# Altium schematic sheet ESP32.SchDoc(ESP32)
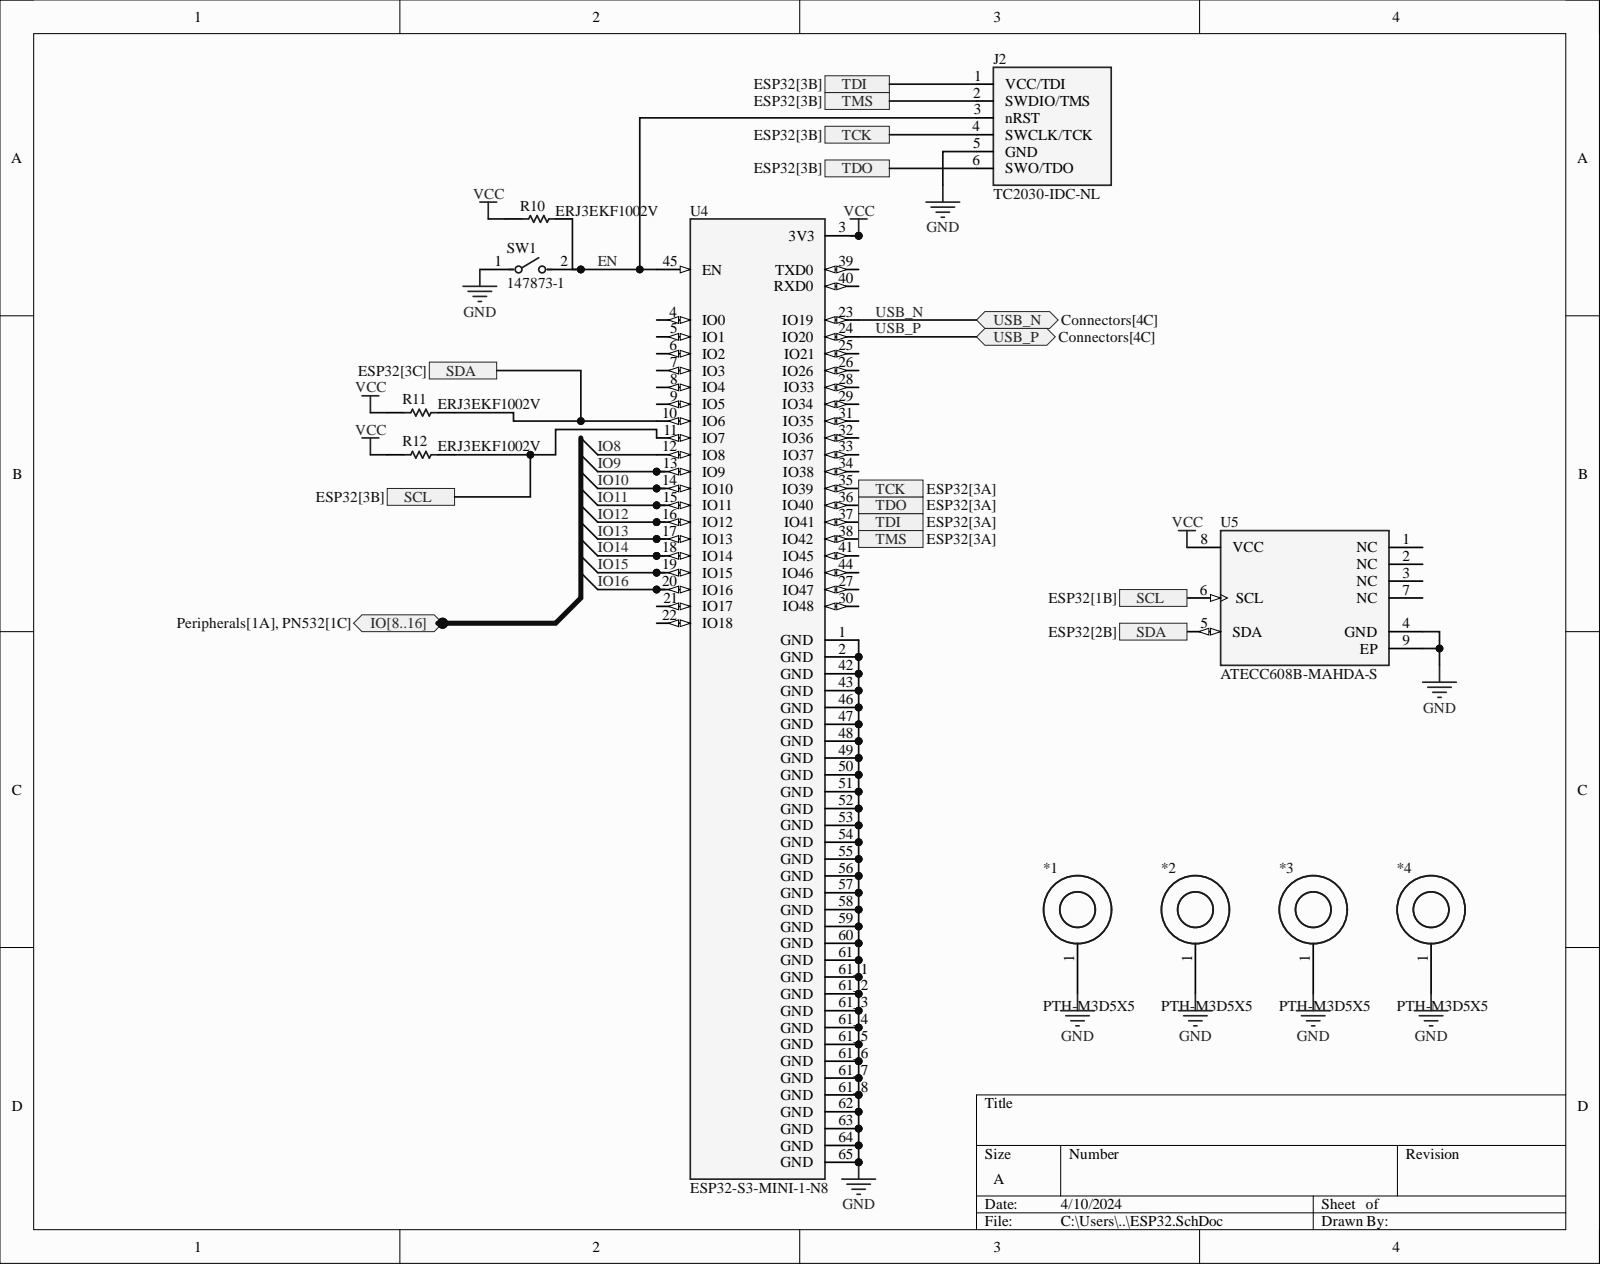
[diagram p0: ESP32.SchDoc]

## Parts
*1  PTH-M3D5X5  [PTH-M3D5X5-Footprint-1]
*2  PTH-M3D5X5  [PTH-M3D5X5-Footprint-1]
*3  PTH-M3D5X5  [PTH-M3D5X5-Footprint-1]
*4  PTH-M3D5X5  [PTH-M3D5X5-Footprint-1]
J2  TC2030-IDC-NL  [TAG_TC2030-IDC-NL]
R10  ERJ3EKF1002V  [RESC1609X55X30LL15T15]
R11  ERJ3EKF1002V  [RESC1609X55X30LL15T15]
R12  ERJ3EKF1002V  [RESC1609X55X30LL15T15]
SW1  147873-1  [FP-147873-1-MFG]
U4  ESP32-S3-MINI-1-N8  [XCVR_ESP32-S3-MINI-1-N8]
U5  ATECC608B-MAHDA-S  [FP-C04-21355-Q4B-IPC_B]

## Ports
IO8, IO9, IO10, IO11, IO12, IO13, IO14, IO15, IO16, IO[8..16], SCL, SDA, TCK, TDI, TDO, TMS, USB_N, USB_P

## Nets
GND: *1.1 *2.1 *3.1 *4.1 J2.5(GND) SW1.1 U4.1(GND) U4.2(GND) U4.42(GND) U4.43(GND) U4.46(GND) U4.47(GND) U4.48(GND) U4.49(GND) U4.50(GND) U4.51(GND) U4.52(GND) U4.53(GND) U4.54(GND) U4.55(GND) U4.56(GND) U4.57(GND) U4.58(GND) U4.59(GND) U4.60(GND) U4.61(GND) U4.61_1(GND) U4.61_2(GND) U4.61_3(GND) U4.61_4(GND) U4.61_5(GND) U4.61_6(GND) U4.61_7(GND) U4.61_8(GND) U4.62(GND) U4.63(GND) U4.64(GND) U4.65(GND) U5.4(GND) U5.9(EP)
VCC: R10.2 R11.2 R12.2 U4.3(3V3) U5.8(VCC)
EN: J2.3(nRST) R10.1 SW1.2 U4.45(EN)
IO8: U4.12(IO8) ; port IO[8..16]  [also labelled ]
IO9: U4.13(IO9) ; port IO[8..16]  [also labelled ]
IO10: U4.14(IO10) ; port IO[8..16]  [also labelled ]
IO11: U4.15(IO11) ; port IO[8..16]  [also labelled ]
IO12: U4.16(IO12) ; port IO[8..16]  [also labelled ]
IO13: U4.17(IO13) ; port IO[8..16]  [also labelled ]
IO14: U4.18(IO14) ; port IO[8..16]  [also labelled ]
IO15: U4.19(IO15) ; port IO[8..16]  [also labelled ]
IO16: U4.20(IO16) ; port IO[8..16]  [also labelled ]
SCL: R12.1 U4.11(IO7) U5.6(SCL) ; port SCL
SDA: R11.1 U4.10(IO6) U5.5(SDA) ; port SDA
TCK: J2.4(SWCLK/TCK) U4.35(IO39) ; port TCK
TDI: J2.1(VCC/TDI) U4.37(IO41) ; port TDI
TDO: J2.6(SWO/TDO) U4.36(IO40) ; port TDO
TMS: J2.2(SWDIO/TMS) U4.38(IO42) ; port TMS
USB_N: U4.23(IO19) ; port USB_N
USB_P: U4.24(IO20) ; port USB_P

Not connected: U4 4(IO0) 5(IO1) 6(IO2) 7(IO3) 8(IO4) 9(IO5) 21(IO17) 22(IO18) 25(IO21) 26(IO26) 27(IO47) 28(IO33) 29(IO34) 30(IO48) 31(IO35) 32(IO36) 33(IO37) 34(IO38) 39(TXD0) 40(RXD0) 41(IO45) 44(IO46); U5 1(NC) 2(NC) 3(NC) 7(NC)

## Library data of the parts
*1-*4 = CMP-010-00024-1: Plated Thru-Hole M3 D5X5; Component Kind: Standard; Mounting Technology: TH; Pins: 1; Library Name: Purdue University
J2 = CMP-070-000001-2: CABLE ADAPTER 6 POS; Availability: Unavailable; Component Kind: Standard; Component Type: Standard; Design Item ID: TC2030-IDC-NL; MP: TC2030-IDC-NL; Package: None; Pin Count: 6; Price: None; Source: TC2030-IDC-NL.SchLib; Library Name: Purdue University
R10-R12 = CMP-1012-00623-3: Chip Resistor, 10 KOhm, +/- 1%, 0.1 W, -55 to 155 degC, 0603 (1608 Metric), RoHS, Tape and Reel; Manufacturer: Panasonic; Case\/Package: 0603; Max Power Dissipation: 100mW; Resistance: 10KOhm; Temperature Coefficient: 100ppm\/degreesC; Voltage Rating: 75V; Case Code (Imperial): 0603; Case Code (Metric): 1608; Component Kind: Standard; Composition: ThickFilm; Contact Plating: Tin; Datasheet URL: https://industrial.panasonic.com/cdbs/www-data/pdf/RDA0000/AOA0000C304.pdf; Datasheet Version: 02/2017; Depth: 0.8mm; Height: 0.45mm; Lead Free: LeadFree; Length: 1.6mm; Library Name: Altium Content Vault; Manufacturer URL: http://www.panasonic.com/; Max Operating Temperature: 155degC; Military Standard: Not; Min Operating Temperature: -55degC; Mount: SurfaceMount; Mounting Technology: SurfaceMount; Number of Pins: 2; Number of Terminations: 2; Package Description: 2-Pin Surface Mount Device, Body 1.6 x 0.85 mm; Package Quantity: 1; Package Reference: 603; Packaging: TapeandReel; Power Rating: 100mW; Radiation Hardening: No; REACH SVHC: NoSVHC; RoHSCompliant: true; Termination: SMD/SMT; Tolerance: 1%; Voltage Rating (DC): 75V; Width: 0.031inch
SW1 = CMP-03407-000005-1: SWITCH TACTILE SPST-NO 0.05A 24V; Manufacturer: TE Connectivity; Contact Current Rating: 50mA; Contact Resistance: 100mΩ; Electromechanical Life: 40000Cycles; Operating Force: 180gf; Automotive: No; Category: Switch; Component Kind: Standard; ComponentLink2Description: Datasheet; ComponentLink2URL: https://www.te.com/commerce/DocumentDelivery/DDEController?Action=showdoc&DocId=Customer+Drawing%7F147873%7FK3%7Fpdf%7FEnglish%7FENG_CD_147873_K3.pdf%7F147873-1; Device Class L1: Electromechanical; Device Class L2: Switches; Device Class L3: Tactile Switches; Digikey Description: SWITCH TACTILE SPST-NO 0.05A 24V; Height: 5.5mm; Lead Free: Yes; Library Name: Altium Content Vault; Manufacturer Part Number: 147873-1; Mount: Surface Mount; Mouser Description: Switch Tactile OFF (ON) SPST Rectangular Button J-Bend 0.05A 24VDC 1.77N SMD T/R; Octopart: https://octopart.com/147873-1-te+connectivity-40818451; Package: 0; RoHS: TRUE; Temperature: -35°C to +85°C; Temperature Range High: +85°C; Temperature Range Low: -35°C; Throw Configuration: SPST-NO; Voltage Rating Dc: 24V
U4 = ESP32-S3-MINI-1-N8: Bluetooth, WiFi 802.11b/g/n, Bluetooth v5.0 Transceiver Module 2.4GHz PCB Trace Surface Mount; MF: {'manufacturer': u'Espressif Systems'}; Availability: Unavailable; Component Kind: Standard; MP: ESP32-S3-MINI-1-N8; Package: None; Price: None
U5 = CMP-06260-000008-1: IC AUTHENTICATION CHIP; Component Kind: Standard; Library Name: Altium Content Vault
